# Altium schematic sheet SoM_mikroBUS_SAM21.SchDoc(SoM_mikroBUS_SAM21)
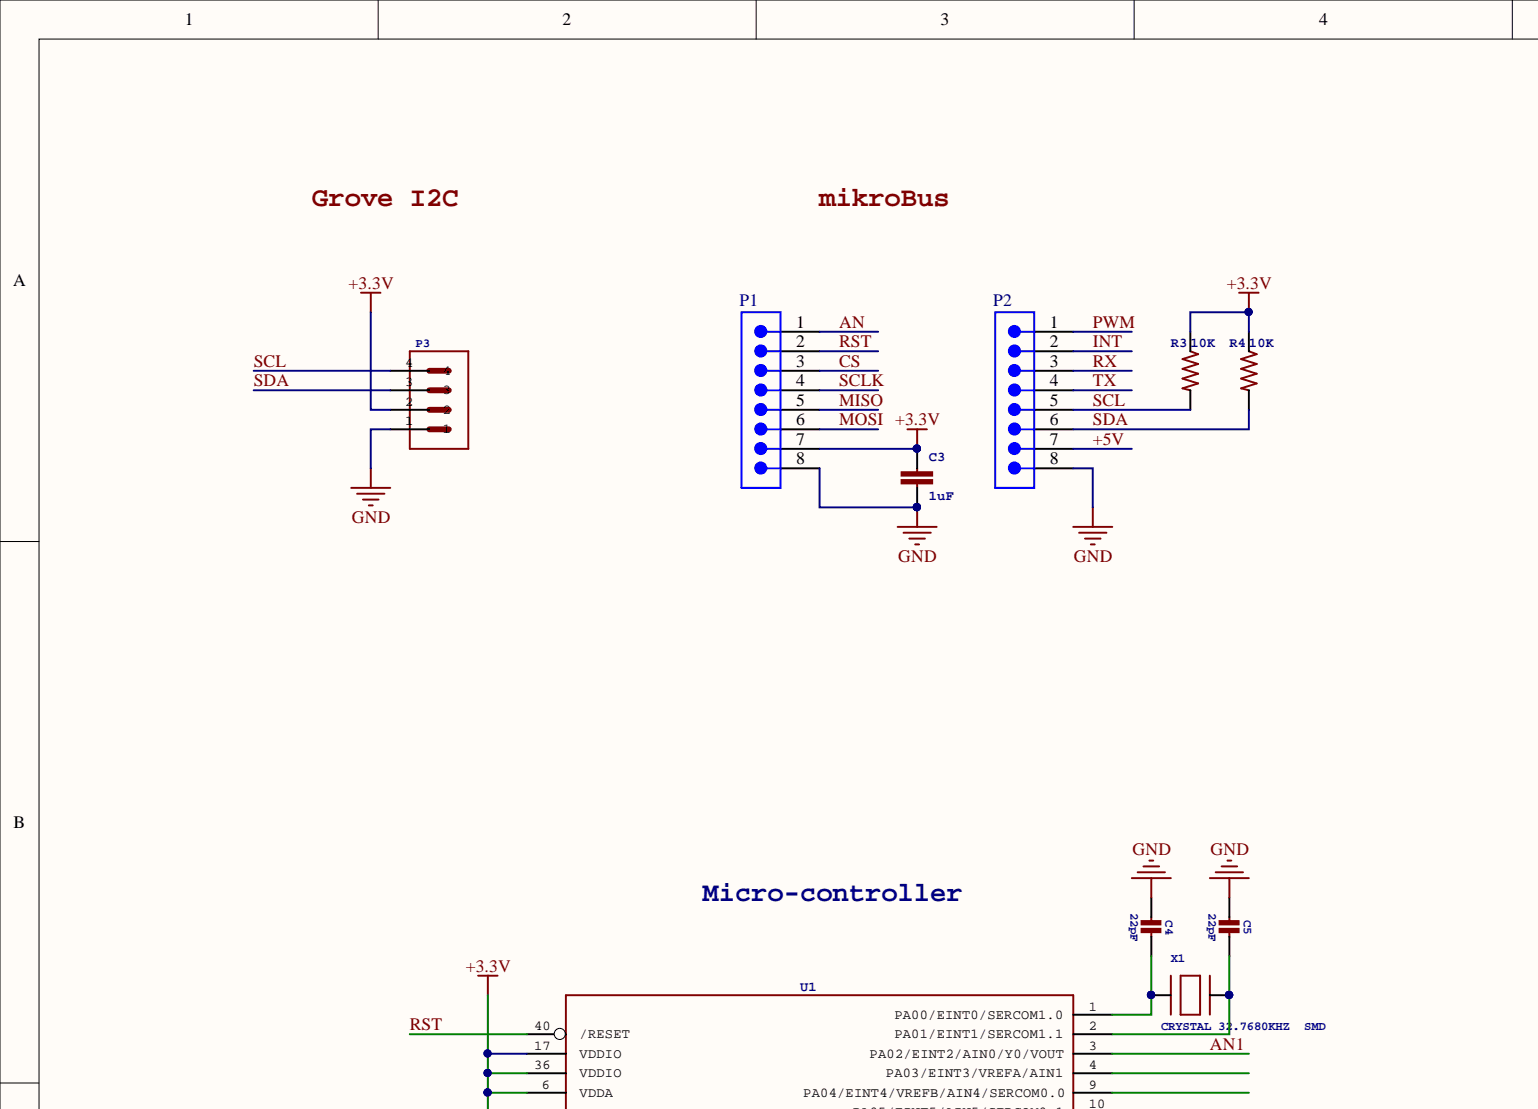
[diagram p0: SoM_mikroBUS_SAM21.SchDoc, top left]
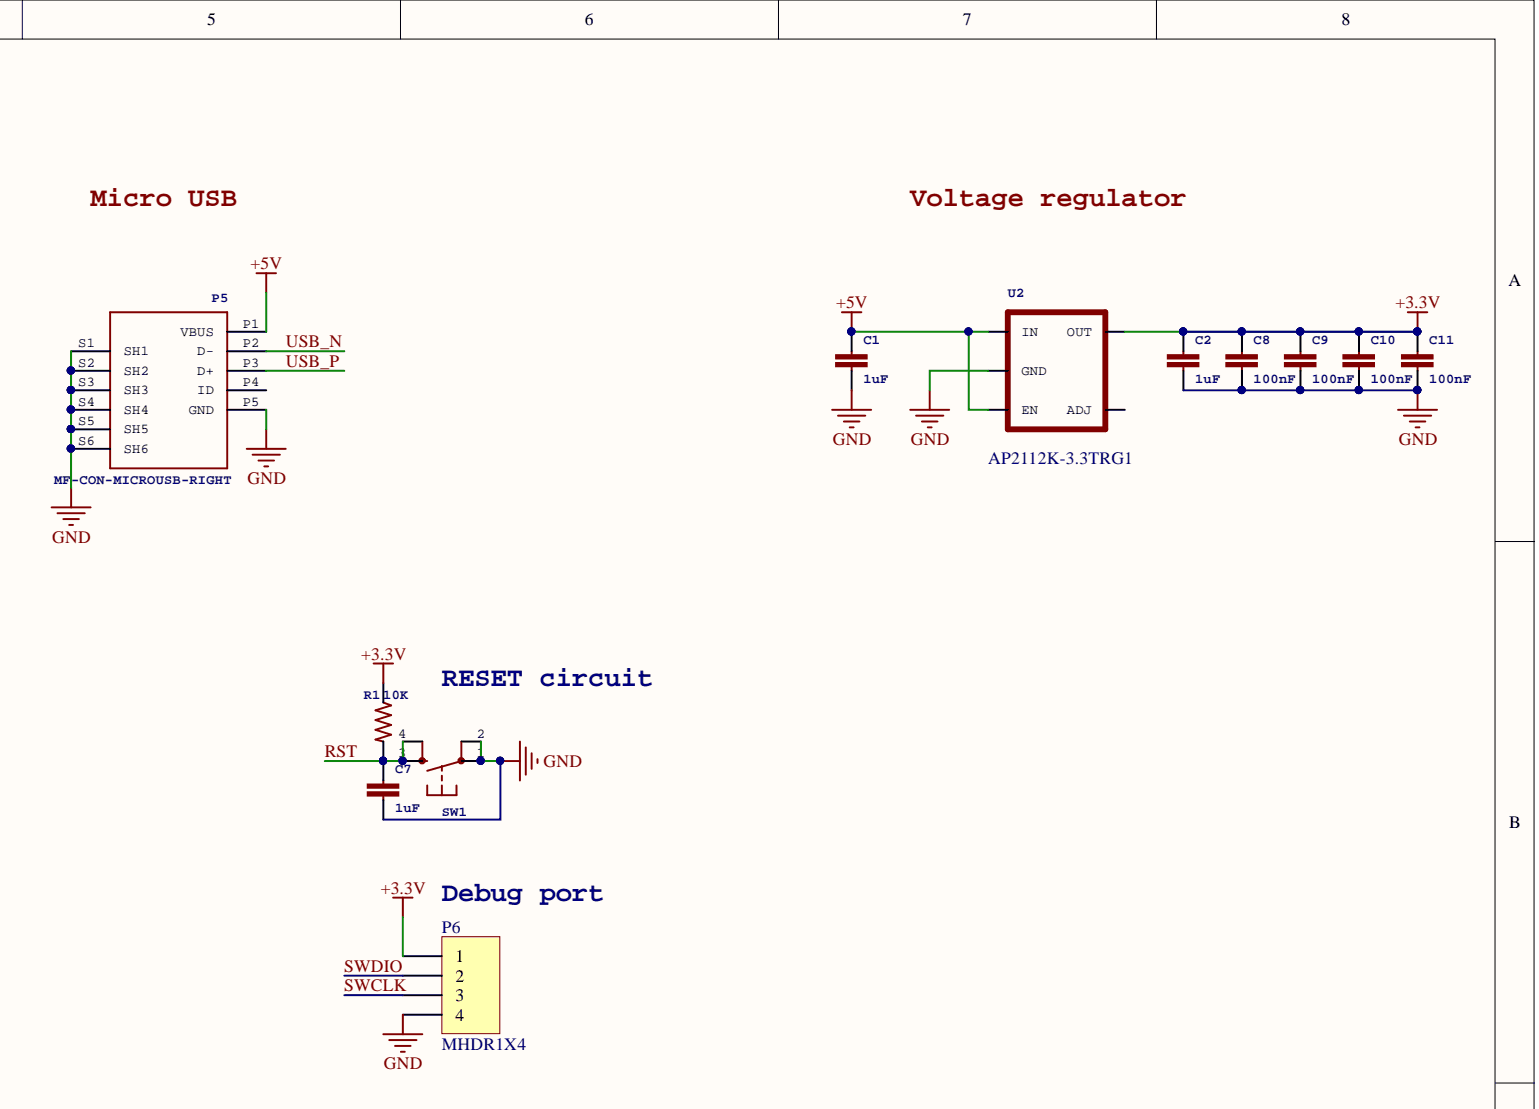
[diagram p1: SoM_mikroBUS_SAM21.SchDoc, top right]
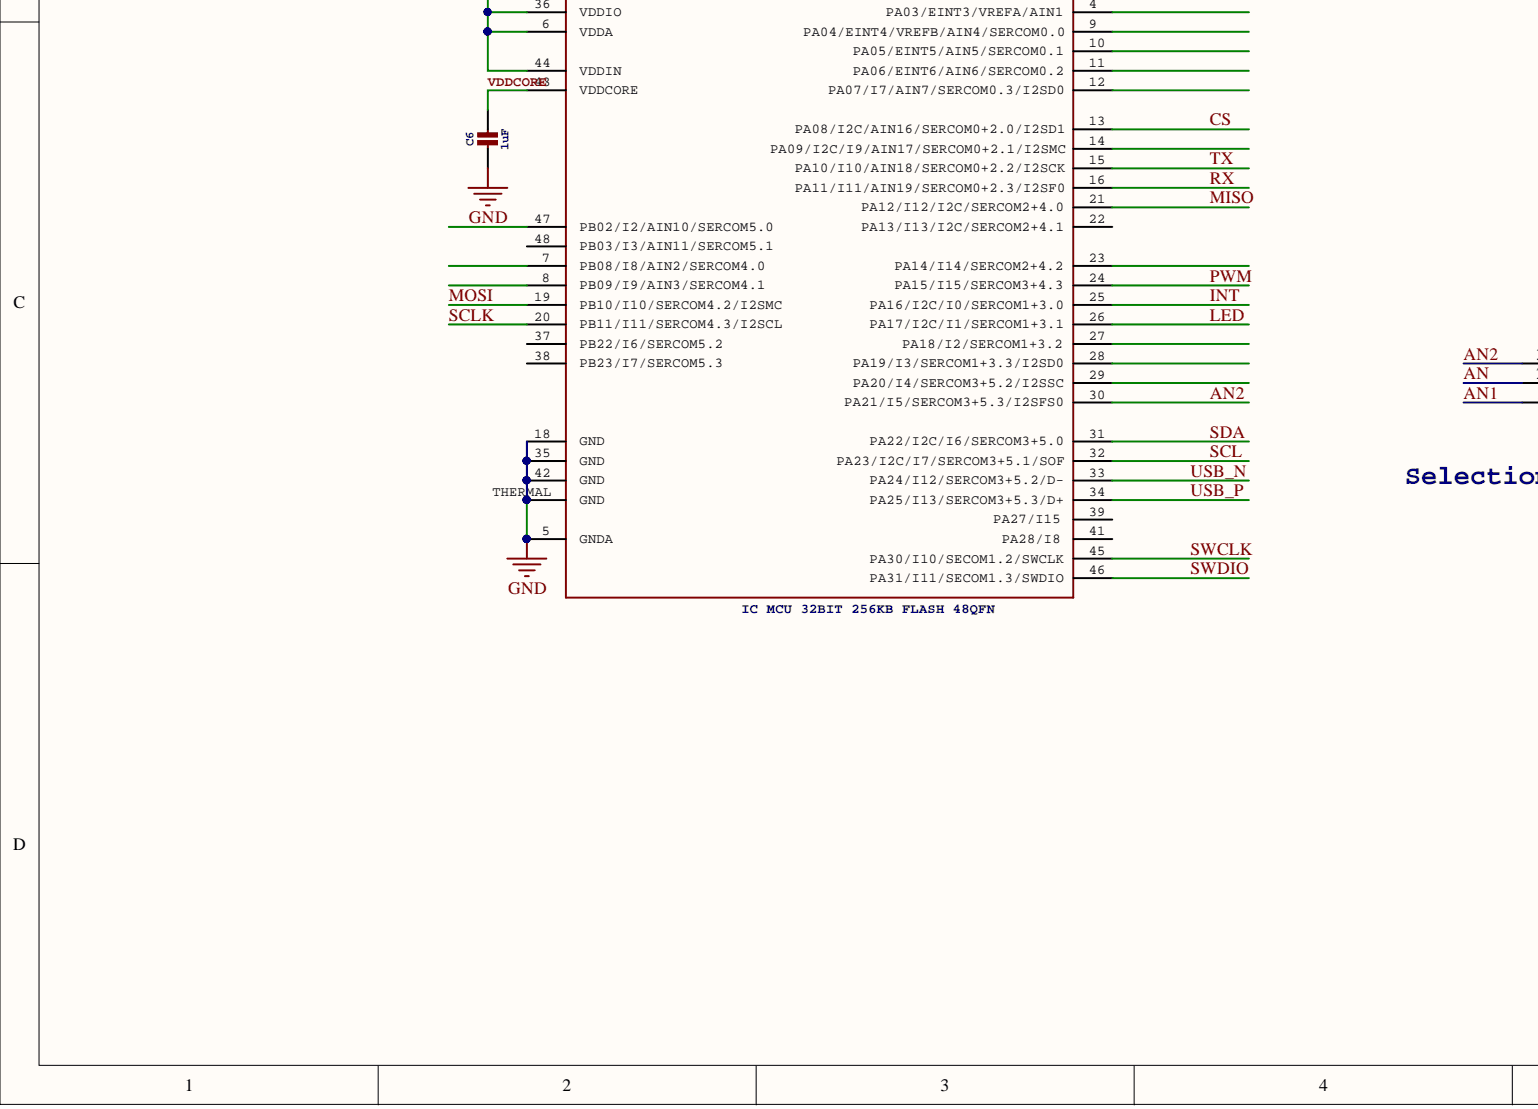
[diagram p2: SoM_mikroBUS_SAM21.SchDoc, bottom left]
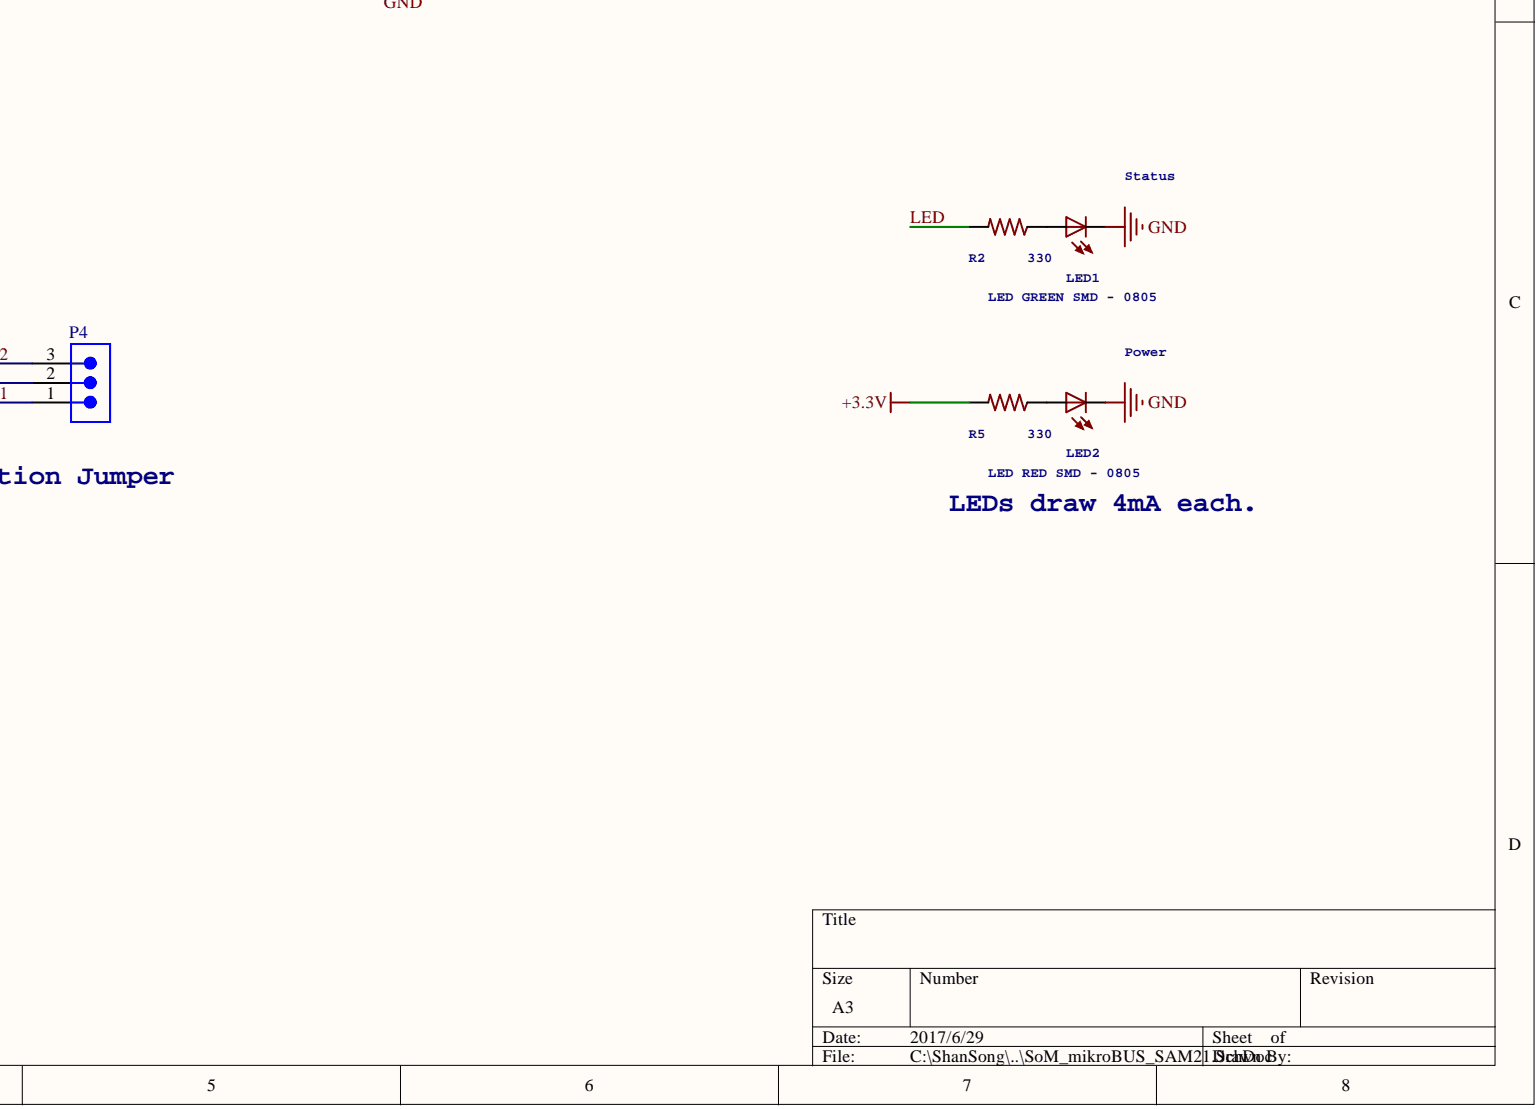
[diagram p3: SoM_mikroBUS_SAM21.SchDoc, bottom right]

## Parts
C1  1uF  [0402-CAP]
C2  1uF  [0402-CAP]
C3  1uF  [0402-CAP]
C4  22pF  [0402-CAP]
C5  22pF  [0402-CAP]
C6  1uF  [0402-CAP]
C7  1uF  [0402-CAP]
C8  100nF  [0402-CAP]
C9  100nF  [0402-CAP]
C10  100nF  [0402-CAP]
C11  100nF  [0402-CAP]
LED1  LED GREEN SMD - 0805  [CHIPLED_0805]
LED2  LED RED SMD - 0805  [CHIPLED_0805]
P1  SSQ-108-01-T-S  [SMTC-PHT-108-01-X-S]  (value not drawn)
P2  SSQ-108-01-T-S  [SMTC-PHT-108-01-X-S]  (value not drawn)
P3  CONN HEADER PH SIDE 4POS 2MM SMD  [JST_S4B-PH-SM4-TB]  (value not drawn)
P4  TSW-103-07-T-S  [SMTC-TSW-103-07-X-S]  (value not drawn)
P5  MF-CON-MICROUSB-RIGHT  [FCI_USB_MICRO_B]
P6  MHDR1X4  [MHDR1X4]
R1  10K  [R0402-VISHAY]
R2  330  [R0402-VISHAY]
R3  10K  [R0402-VISHAY]
R4  10K  [R0402-VISHAY]
R5  330  [R0402-VISHAY]
SW1  SWITCH TACTILE SPST-NO 0.05A 16V  [PTS810]  (value not drawn)
U1  IC MCU 32BIT 256KB FLASH 48QFN  [TQFN48_7MM]
U2  PartNumber: AP2112K-3.3TRG1  [SOT23-5]  (hidden comment: MF-REG-SOT235-3.3V-300mA)
X1  CRYSTAL 32.7680KHZ  SMD  [XTAL3215]

## Nets
+3.3V: C2.1 C3.1 C8.1 C9.1 C10.1 C11.1 P1.7 P3.2 P6.1 R1.1 R3.1 R4.1 R5.2 U1.6(VDDA) U1.17(VDDIO) U1.36(VDDIO) U1.44(VDDIN) U2.5(OUT)
GND: C1.2 C2.2 C3.2 C4.2 C5.2 C6.2 C7.2 C8.2 C9.2 C10.2 C11.2 LED1.C LED2.C P1.8 P2.8 P3.1 P5.P5(GND) P5.S1(SH1) P5.S2(SH2) P5.S3(SH3) P5.S4(SH4) P5.S5(SH5) P5.S6(SH6) P6.4 SW1.1 SW1.2 U1.5(GNDA) U1.18(GND) U1.35(GND) U1.42(GND) U1.THERMAL(GND) U2.2(GND)
+5V: C1.1 P2.7 P5.P1(VBUS) U2.1(IN) U2.3(EN)
AN: P1.1 P4.2
AN1: P4.1 U1.3(PA02/EINT2/AIN0/Y0/VOUT)
AN2: P4.3 U1.30(PA21/I5/SERCOM3+5.3/I2SFS0)
CS: P1.3 U1.13(PA08/I2C/AIN16/SERCOM0+2.0/I2SD1)
INT: P2.2 U1.25(PA16/I2C/I0/SERCOM1+3.0)
LED: R2.2 U1.26(PA17/I2C/I1/SERCOM1+3.1)
MISO: P1.5 U1.21(PA12/I12/I2C/SERCOM2+4.0)
MOSI: P1.6 U1.19(PB10/I10/SERCOM4.2/I2SMC)
PWM: P2.1 U1.24(PA15/I15/SERCOM3+4.3)
RST: C7.1 P1.2 R1.2 SW1.3 SW1.4 U1.40(/RESET)
RX: P2.3 U1.16(PA11/I11/AIN19/SERCOM0+2.3/I2SF0)
SCL: P2.5 P3.4 R3.2 U1.32(PA23/I2C/I7/SERCOM3+5.1/SOF)
SCLK: P1.4 U1.20(PB11/I11/SERCOM4.3/I2SCL)
SDA: P2.6 P3.3 R4.2 U1.31(PA22/I2C/I6/SERCOM3+5.0)
SWCLK: P6.3 U1.45(PA30/I10/SECOM1.2/SWCLK)
SWDIO: P6.2 U1.46(PA31/I11/SECOM1.3/SWDIO)
TX: P2.4 U1.15(PA10/I10/AIN18/SERCOM0+2.2/I2SCK)
USB_N: P5.P2(D-) U1.33(PA24/I12/SERCOM3+5.2/D-)
USB_P: P5.P3(D+) U1.34(PA25/I13/SERCOM3+5.3/D+)
VDDCORE: C6.1 U1.43(VDDCORE)
NetC4_1: C4.1 U1.1(PA00/EINT0/SERCOM1.0) X1.P$1
NetC5_1: C5.1 U1.2(PA01/EINT1/SERCOM1.1) X1.P$2
NetLED1_A: LED1.A R2.1
NetLED2_A: LED2.A R5.1

Not connected: P5 P4(ID); U1 4(PA03/EINT3/VREFA/AIN1) 7(PB08/I8/AIN2/SERCOM4.0) 8(PB09/I9/AIN3/SERCOM4.1) 9(PA04/EINT4/VREFB/AIN4/SERCOM0.0) 10(PA05/EINT5/AIN5/SERCOM0.1) 11(PA06/EINT6/AIN6/SERCOM0.2) 12(PA07/I7/AIN7/SERCOM0.3/I2SD0) 14(PA09/I2C/I9/AIN17/SERCOM0+2.1/I2SMC) 22(PA13/I13/I2C/SERCOM2+4.1) 23(PA14/I14/SERCOM2+4.2) 27(PA18/I2/SERCOM1+3.2) 28(PA19/I3/SERCOM1+3.3/I2SD0) 29(PA20/I4/SERCOM3+5.2/I2SSC) 37(PB22/I6/SERCOM5.2) 38(PB23/I7/SERCOM5.3) 39(PA27/I15) 41(PA28/I8) 47(PB02/I2/AIN10/SERCOM5.0) 48(PB03/I3/AIN11/SERCOM5.1); U2 4(ADJ)

## Library data of the parts
C1-C3 C7 = CAP0402: CAP CER 1UF 10V X7S 0402; PartNumber: C1005X7S1A105K050BC; Manufacturer: TDK; ComponentLink2URL: https://product.tdk.com/info/en/catalog/datasheets/mlcc_commercial_general_en.pdf; ComponentLink2Description: Datasheet; ComponentLink1URL: http://www.tdk.com/; ComponentLink1Description: Manufacturer URL; Component Kind: Standard; Library Name: swift.IntLib
C4 C5 = CAP_CERAMIC0603_NO: CAP CER 22PF 16V C0G 01005; PartNumber: C0402C0G1C220J020BC; Manufacturer: TDK; Library Name: microbuilder.IntLib; ComponentLink2URL: https://product.tdk.com/info/en/catalog/datasheets/mlcc_commercial_general_en.pdf; ComponentLink2Description: Datasheet; ComponentLink1URL: http://www.tdk.com/; ComponentLink1Description: Manufacturer URL; Component Kind: Standard
C6 = CAP_CERAMIC0603_NO: CAP CER 1UF 10V X7S 0402; PartNumber: C1005X7S1A105K050BC; Manufacturer: TDK; Library Name: microbuilder.IntLib; ComponentLink2URL: https://product.tdk.com/info/en/catalog/datasheets/mlcc_commercial_general_en.pdf; ComponentLink2Description: Datasheet; ComponentLink1URL: http://www.tdk.com/; ComponentLink1Description: Manufacturer URL; Component Kind: Standard
C8-C11 = CAP0402: CAP CER 0.1UF 16V X7R 0402; PartNumber: CGA2B1X7R1C104K050BC; Manufacturer: TDK; ComponentLink2URL: https://product.tdk.com/info/en/catalog/datasheets/mlcc_automotive_general_en.pdf; ComponentLink2Description: Datasheet; ComponentLink1URL: http://www.tdk.com/; ComponentLink1Description: Manufacturer URL; Component Kind: Standard; Library Name: swift.IntLib
LED1 = 0044_KPT-2012YC: PartNumber: LTST-C171GKT; Manufacturer: Lite-On Inc; Library Name: Opto.IntLib; Description: LED GREEN SMD - 0805; ComponentLink2URL: https://media.digikey.com/pdf/Data%20Sheets/Lite-On%20PDFs/LTST-C171GKT.pdf; ComponentLink2Description: Datasheet; ComponentLink1URL: http://www.liteon.com; ComponentLink1Description: Manufacturer URL; Component Kind: Standard
LED2 = 0044_KPT-2012YC: PartNumber: LTST-S220KRKT; Manufacturer: Lite-On Inc; Library Name: Opto.IntLib; Description: LED RED SMD - 0805; ComponentLink2URL: http://optoelectronics.liteon.com/upload/download/DS22-2000-137/S_110_LTST-S220KRKT.pdf; ComponentLink2Description: Datasheet; ComponentLink1URL: http://www.liteon.com; ComponentLink1Description: Manufacturer URL; Component Kind: Standard
P1 P2 = CMP-1024-00189-1: Female Header, Pitch 2.54 mm, 1 x 8 Position, Height 8.54 mm, Tail Length 3 mm, -40 to 105 degC, RoHS, Bulk; Manufacturer: Samtec; Pitch: 2.54 mm; AllowReplace: Can be replaced with equivalent part; Temperature Range: -55 to 125 degC; Technology: PTH; RoHS: True; PartNumber: SSQ-108-01-T-S; PackageVersion: J, 9/2009; PackageReference: PHT-108-01-X-S; PackageDescription: Male Header, Pitch 2.54 mm, 1 x 8 Position, Height 8.54 mm, Tail Length 3 mm; Orientation: Vertical; Mounting Technology: Through-hole; Library Name: Altium Content Vault; DatasheetVersion: F-214; ComponentLink3Description: Package Specification; ComponentLink2URL: http://www.samtec.com/documents/webfiles/pdf/SSQ_TH.PDF; ComponentLink2Description: Datasheet; ComponentLink1URL: http://www.samtec.com; ComponentLink1Description: Manufacturer URL; Component Kind: Standard
  P2: ComponentLink3URL: http://www.nb-kaifeng.com/
P3 = S4B-PH-SM4-TB: Manufacturer: JST; PartNumber: S4B-PH-SM4-TB; Library Name: S4B-PH-SM4-TB.SCHLIB; Description: CONN HEADER PH SIDE 4POS 2MM SMD; ComponentLink2URL: http://www.jst-mfg.com/product/pdf/eng/ePH.pdf; ComponentLink2Description: Datasheet; ComponentLink1URL: http://www.jst.com/; ComponentLink1Description: Manufacturer URL; Component Kind: Standard
P4 = CMP-1024-00332-1: 0.025" SQ Post Header, Through-hole, Vertical, -55 to 105 degC, 2.54 mm Pitch, 12-Pin, 1x3  Male, RoHS; PartNumber: KF1014-P 1*3 1BV; Manufacturer: Cixi KaiFeng; Pitch: 2.54 mm; Temperature Range: -55 to 105 degC; RoHS: True; PackageVersion: DM, 8/1988; PackageReference: TSW-104-07-X-T; PackageDescription: .025[0.64] SQ. Post Terminal Strip Assembly; Orientation: Vertical; Mounting Technology: Through-hole; Library Name: Altium Content Vault; DatasheetVersion: F-214; ComponentLink3URL: http://www.samtec.com/documents/webfiles/cpdf/TSW-XXX-XX-XXX-X-XX-XXX-MKT.pdf; ComponentLink3Description: Package Specification; ComponentLink2URL: http://www.nb-kaifeng.com/product/product1262.html; ComponentLink2Description: Datasheet; ComponentLink1URL: http://www.nb-kaifeng.com/; ComponentLink1Description: Manufacturer URL; Component Kind: Standard
P5 = USB_MICRO_B_FCI: CONN USB MICRO B RECPT SMT R/A; PartNumber: 10118193-0001LF; Manufacturer: Amphenol FCI; ComponentLink2URL: http://portal.fciconnect.com/Comergent//fci/drawing/10118193.pdf; ComponentLink2Description: Datasheet; ComponentLink1URL: http://www.amphenol.com/; ComponentLink1Description: Manufacturer URL; Component Kind: Standard; Library Name: swift.IntLib
P6 = MHDR1X4: Male Header, Pitch 1.27mm, 1 x 4 Position, RoHS, Bulk; PartNumber: BD020-04-AJ-0350-0300-LG; Manufacturer: GCT (GLOBAL CONNECTOR TECHNOLOGY); Signal Integrity: Connector; Library Name: Miscellaneous Connectors.IntLib; LatestRevisionNote: Re-released for DXP Platform.; LatestRevisionDate: 17-Jul-2002; ComponentLink2URL: http://www.farnell.com/datasheets/663087.pdf?_ga=2.166784254.1961090300.1498682731-2053240826.1498682731; ComponentLink2Description: Datasheet; ComponentLink1URL: https://gct.co/; ComponentLink1Description: Manufacturer URL; Component Kind: Standard; Publisher: Altium Limited
R1 R3 R4 = R-US_R0402-VISHAY: RES SMD 10K OHM 1% 1/16W 0402; PartNumber: RC0402FR-0710KL; Manufacturer: Yageo; Library Name: adafruit.IntLib; ComponentLink2URL: http://www.yageo.com/documents/recent/PYu-RC_Group_51_RoHS_L_7.pdf; ComponentLink2Description: Datasheet; ComponentLink1URL: http://www.yageo.com; ComponentLink1Description: Manufacturer URL; Component Kind: Standard
R2 R5 = R-US_R0402-VISHAY: RES SMD 330 OHM 1% 1/16W 0402; PartNumber: RC0402FR-07330RL; Manufacturer: Yageo; Library Name: adafruit.IntLib; ComponentLink2URL: http://www.yageo.com/documents/recent/PYu-RC_Group_51_RoHS_L_7.pdf; ComponentLink2Description: Datasheet; ComponentLink1URL: http://www.yageo.com; ComponentLink1Description: Manufacturer URL; Component Kind: Standard
SW1 = SPST_TACT-PTS810: PartNumber: PTS810 SJM 250 SMTR LFS; Manufacturer: C&K; Library Name: adafruit.IntLib; Description: SWITCH TACTILE SPST-NO 0.05A 16V; ComponentLink2URL: http://www.ckswitches.com/media/1476/pts810.pdf; ComponentLink2Description: Datasheet; ComponentLink1URL: http://www.ckswitches.com/; ComponentLink1Description: Manufacturer URL; Component Kind: Standard
U1 = ATSAMD21J_QFN: PartNumber: ATSAMD21G18A-MUT; Manufacturer: Atmel; Library Name: SoM_mikroBUS_SAM21.SCHLIB; Description: IC MCU 32BIT 256KB FLASH 48QFN; ComponentLink2URL: http://www.microchip.com/mymicrochip/filehandler.aspx?ddocname=en590209; ComponentLink2Description: Datasheet; ComponentLink1URL: http://www.atmel.com; ComponentLink1Description: Manufacturer URL; Component Kind: Standard
U2 = AP2202-ADJ: AP2112 Adjustable LDO Diodes Inc LDO 150mA, 13.2V, 3.3V out less than 200mV dropout; Manufacturer: Texas Instruments; PROD_ID: VREG-12189; Library Name: SparkFun-PowerIC.IntLib; ComponentLink2URL: https://www.diodes.com/assets/Datasheets/AP2112.pdf; ComponentLink2Description: Datasheet; ComponentLink1URL: https://www.diodes.com; ComponentLink1Description: Manufacturer URL; Component Kind: Standard
X1 = XTAL-3.2X1.5: CRYSTAL 32.7680KHZ SMD; PartNumber: NX3215SA-32.768K-STD-MUS-2; Manufacturer: NDK America, Inc.; Library Name: adafruit2.IntLib; ComponentLink2URL: http://www.ndk.com/images/products/catalog/c_NX3215SA-STD-MUA-14_e.pdf; ComponentLink2Description: Datasheet; ComponentLink1URL: http://www.ndk.com; ComponentLink1Description: Manufacturer URL; Component Kind: Standard
